# Altium schematic sheet PreCharge.SchDoc(PreCharge)
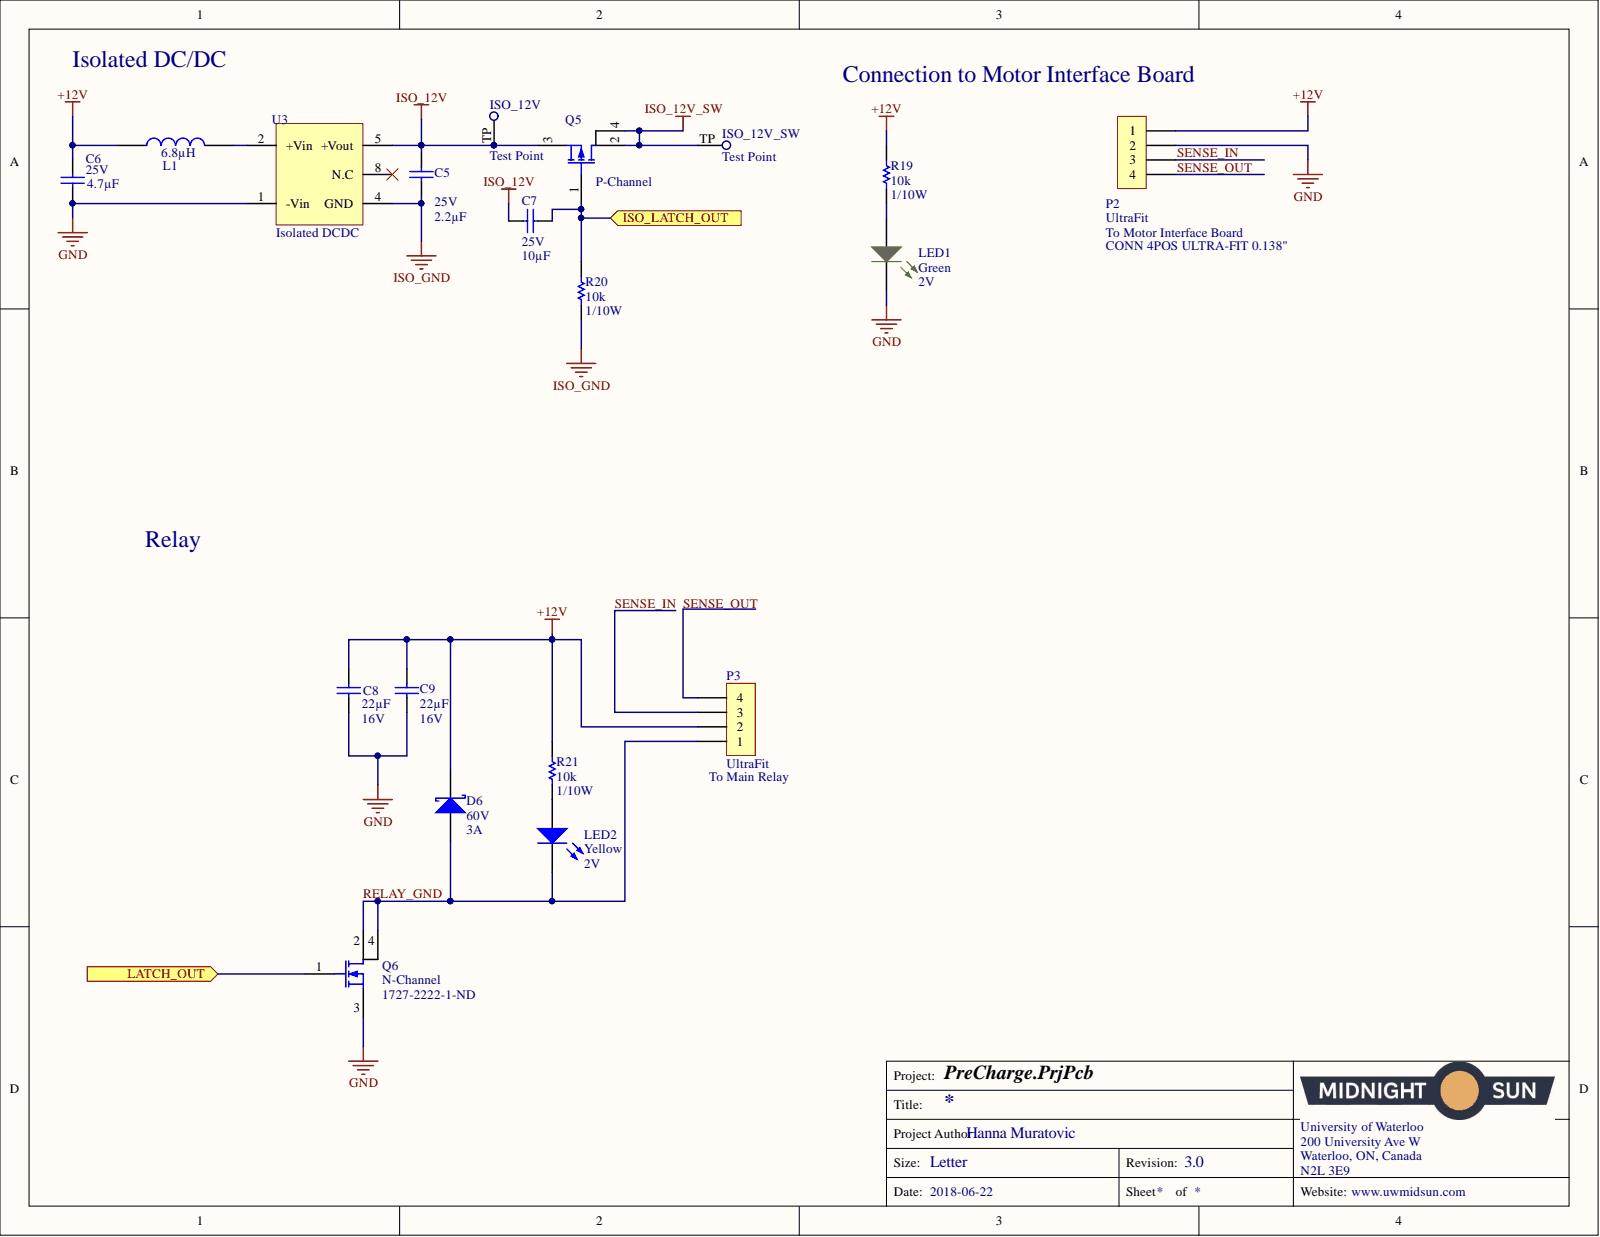
[diagram p0: PreCharge.SchDoc]

## Parts
C5  Voltage: 25V  Capacitance: 2.2µF  [CAP, 0603]  (hidden comment: CAP CER 2.2UF 25V 10% X5R 0603)
C6  Voltage: 25V  Capacitance: 4.7µF  [CAP, 0603]  (hidden comment: CAP CER 4.7UF 25V 10% X5R 0603)
C7  Voltage - Rated: 25V  Capacitance: 10µF  [CAP, 0805]  (hidden comment: CAP CER 10uF 25V 10% X5R 0805)
C8  Voltage: 16V  Capacitance: 22µF  [CAP, 1210]  (hidden comment: CAP CER 22UF 16V ±20% X5R 1210)
C9  Voltage: 16V  Capacitance: 22µF  [CAP, 1210]  (hidden comment: CAP CER 22UF 16V ±20% X5R 1210)
D6  Voltage - DC Reverse (Vr) (Max): 60V  Current - Average Rectified (Io): 3A  [SMA]  (hidden comment: DIODE SCHOTTKY 60V 3A SMA)
ISO_12V  Test Point  [Test Point]  (no BOM)
ISO_12V_SW  Test Point  [Test Point]  (no BOM)
L1  Inductance: 6.8µH  [NLFV32T-6R8M-EF/IND 6.8uH]  (hidden comment: IND 6.8uH 260mA 20% 1210)
LED1  Voltage - Forward (Vf) (Typ): 2V  Color: Green  [LED_0603]  (hidden comment: LED GREEN CLEAR 2V 0603)
LED2  Voltage - Forward (Vf) (Typ): 2V  Color: Yellow  [LED_0603]  (hidden comment: LED YELLOW CLEAR 2.1V 0603)
P2  CONN 4POS ULTRA-FIT 0.138"  [CONN, 4POS ULTRA-FIT]
P3  CONN 4POS ULTRA-FIT 0.138"  Series: UltraFit  [CONN, 4POS ULTRA-FIT]
Q5  P-Channel  [SOT223_N]
Q6  N-Channel  Supplier Part Number 1: 1727-2222-1-ND  [SOT223_N]
R19  Resistance: 10k  Power: 1/10W  [RES, 0603]  (hidden comment: RES 10K OHM 1% 1/10W 0603)
R20  Resistance: 10k  Power: 1/10W  [RES, 0603]  (hidden comment: RES 10K OHM 1% 1/10W 0603)
R21  Resistance: 10k  Power: 1/10W  [RES, 0603]  (hidden comment: RES 10K OHM 1% 1/10W 0603)
U3  Type: Isolated DCDC  [IC, Isolated DCDC]  (hidden comment: IC DCDC ISOLATED 12V 1W 8-SMD 5-LEAD)

## Ports
ISO_LATCH_OUT, LATCH_OUT

## Nets
+12V: C6.2 C8.1 C9.1 D6.2 L1.1 P2.1 P3.2 R19.2 R21.1
GND: C6.1 C8.2 C9.2 LED1.2 P2.2 Q6.3 U3.1(-Vin)
ISO_12V: C5.2 C7.1 ISO_12V.TP Q5.3 U3.5(+Vout)
ISO_12V_SW: ISO_12V_SW.TP Q5.2 Q5.4
ISO_GND: C5.1 R20.2 U3.4(GND)
ISO_LATCH_OUT: C7.2 Q5.1 R20.1 ; port ISO_LATCH_OUT
LATCH_OUT: Q6.1 ; port LATCH_OUT
RELAY_GND: D6.1 LED2.2 P3.1 Q6.2 Q6.4
SENSE_IN: P2.3 P3.3
SENSE_OUT: P2.4 P3.4
NetL1_2: L1.2 U3.2(+Vin)
NetLED1_1: LED1.1 R19.1
NetLED2_1: LED2.1 R21.2

Not connected: U3 8(N.C)

## Library data of the parts
C5 = CAP CER 2.2UF 25V 10% X5R 0603: 2.2µF ±10% 25V Ceramic Capacitor X5R 0603 (1608 Metric); Manufacturer Part Number 1: GRM188R61E225KA12D; Manufacturer 1: Murata Electronics North America; Tolerance: ±10%; Thickness (Max): 0.035" (0.90mm); Temperature Coefficient: X5R; Supplier Part Number 1: 490-10731-1-ND; Supplier 1: Digi-Key; Size / Dimension: 0.063" L x 0.031" W (1.60mm x 0.80mm); Ratings: -; Part Status: Active; Packaging: Cut Tape (CT); Package / Case: 0603 (1608 Metric); Operating Temperature: -55°C ~ 85°C; Mounting Type: Surface Mount, MLCC; Lead Style: -; Lead Spacing: -; Height - Seated (Max): -; ComponentLink1URL: http://www.murata.com/~/media/webrenewal/support/library/catalog/products/capacitor/mlcc/c02e.ashx; ComponentLink1Description: http://www.murata.com/~/media/webrenewal/support/library/catalog/products/capacitor/mlcc/c02e.ashx; Component Kind: Standard; Library Name: Schematic Diagrams.SchLib
C6 = CAP CER 4.7UF 25V 10% X5R 0603: 4.7µF ±10% 25V Ceramic Capacitor X5R 0603 (1608 Metric); Manufacturer Part Number 1: GRM188R61E475KE11D; Manufacturer 1: Murata Electronics North America; Tolerance: ±10%; Thickness (Max): 0.031" (0.80mm); Temperature Coefficient: X5R; Supplier Part Number 1: 490-7203-1-ND; Supplier 1: Digi-Key; Size / Dimension: 0.063" L x 0.031" W (1.60mm x 0.80mm); Ratings: -; Part Status: Active; Packaging: Cut Tape (CT); Package / Case: 0603 (1608 Metric); Operating Temperature: -55°C ~ 85°C; Mounting Type: Surface Mount, MLCC; Lead Style: -; Lead Spacing: -; Height - Seated (Max): -; ComponentLink1URL: http://www.murata.com/~/media/webrenewal/support/library/catalog/products/capacitor/mlcc/c02e.ashx; ComponentLink1Description: http://www.murata.com/~/media/webrenewal/support/library/catalog/products/capacitor/mlcc/c02e.ashx; Component Kind: Standard; Library Name: Schematic Diagrams.SchLib
C7 = CAP CER 10uF 25V 10% X5R 0805: 10µF ±10% 25V Ceramic Capacitor X5R 0805 (2012 Metric); Manufacturer Part Number: GRM21BR61E106KA73L; Manufacturer: Murata Electronics North America; Tolerance: ±10%; Thickness (Max): 0.053" (1.35mm); Temperature Coefficient: X5R; Supplier Part Number 1: 490-5523-1-ND; Supplier 1: Digi-Key; Stock 1: 1695157; Size / Dimension: 0.079" L x 0.049" W (2.00mm x 1.25mm); RoHS: RoHS Compliant; Ratings: -; Pricing 1: 1=0.3, 10=0.214, 100=0.1008, 500=0.07068, 1000=0.05851 (CAD); Part Status: Active; Packaging: Cut Tape (CT); Package / Case: 0805 (2012 Metric); Operating Temperature: -55°C ~ 85°C; Mounting Type: Surface Mount, MLCC; Lead Style: -; Lead Spacing: -; Height - Seated (Max): -; Features: -; ComponentLink1URL: http://search.murata.co.jp/Ceramy/image/img/A01X/G101/ENG/GRM21BR61E106KA73-01.pdf; ComponentLink1Description: http://search.murata.co.jp/Ceramy/image/img/A01X/G101/ENG/GRM21BR61E106KA73-01.pdf; Component Kind: Standard; Category: Capacitors; Applications: General Purpose; Library Name: Schematic Diagrams.SchLib
C8 C9 = CAP CER 22UF 16V ±20% X5R 1210: 22µF ±20% 16V Ceramic Capacitor X5R 1210 (3225 Metric); Manufacturer Part Number 1: GRM32ER61C226ME20L; Manufacturer 1: Murata Electronics North America; Tolerance: ±20%; Thickness (Max): 0.106" (2.70mm); Temperature Coefficient: X5R; Supplier Part Number 1: 490-1881-1-ND; Supplier 1: Digi-Key; Size / Dimension: 0.126" L x 0.098" W (3.20mm x 2.50mm); Ratings: -; Part Status: Active; Packaging: Cut Tape (CT); Package / Case: 1210 (3225 Metric); Operating Temperature: -55°C ~ 85°C; Mounting Type: Surface Mount, MLCC; Lead Style: -; Lead Spacing: -; Height - Seated (Max): -; ComponentLink1URL: http://www.murata.com/~/media/webrenewal/support/library/catalog/products/capacitor/mlcc/c02e.ashx; ComponentLink1Description: http://www.murata.com/~/media/webrenewal/support/library/catalog/products/capacitor/mlcc/c02e.ashx; Component Kind: Standard; Library Name: Schematic Diagrams.SchLib
D6 = DIODE SCHOTTKY 60V 3A SMA: Diode Schottky 60V 3A Surface Mount SMA; Manufacturer Part Number 1: B360A-13-F; Manufacturer 1: Diodes Incorporated; Voltage - Forward (Vf) (Max) @ If: 700mV @ 3A; Speed: Fast Recovery =< 500ns, > 200mA (Io); Current - Reverse Leakage @ Vr: 500µA @ 60V; Capacitance @ Vr, F: 200pF @ 4V, 1MHz; Supplier Part Number 1: B360A-FDICT-ND; Supplier Device Package: SMA; Supplier 1: Digi-Key; Part Status: Active; Packaging: Cut Tape (CT); Package / Case: DO-214AC, SMA; Operating Temperature - Junction: -55°C ~ 150°C; Mounting Type: Surface Mount; Diode Type: Schottky; ComponentLink1URL: https://www.diodes.com/assets/Datasheets/ds30891.pdf; ComponentLink1Description: https://www.diodes.com/assets/Datasheets/ds30891.pdf; Component Kind: Standard; Library Name: Schematic Diagrams.SchLib
L1 = IND 6.8uH 260mA 20% 1210: 6.8µH Shielded Wirewound Inductor 260mA 204 mOhm Max 1210 (3225 Metric); Manufacturer Part Number 1: NLFV32T-6R8M-EF; Manufacturer 1: TDK Corporation; Material - Core: Ferrite; Type: Wirewound; Tolerance: ±20%; Supplier Part Number 1: 445-15776-1-ND; Supplier Device Package: 1210 (3225 Metric); Supplier 1: Digi-Key; Size / Dimension: 0.126" L x 0.098" W (3.20mm x 2.50mm); Shielding: Shielded; Ratings: -; Q @ Freq: -; Part Status: Active; Packaging: Cut Tape (CT); Package / Case: 1210 (3225 Metric); Operating Temperature: -40°C ~ 105°C; Mounting Type: Surface Mount; Height - Seated (Max): 0.094" (2.40mm); Frequency - Self Resonant: -; DC Resistance (DCR): 204 mOhm Max; Current Rating: 260mA; Current - Saturation: -; ComponentLink1URL: https://product.tdk.com/info/en/catalog/datasheets/inductor_commercial_decoupling_nlfv32-ef_en.pdf; ComponentLink1Description: https://product.tdk.com/info/en/catalog/datasheets/inductor_commercial_decoupling_nlfv32-ef_en.pdf; Component Kind: Standard; Library Name: Schematic Diagrams.SchLib
LED1 = LED GREEN CLEAR 2V 0603: Green 572nm LED Indication - Discrete 2V 0603 (1608 Metric); Manufacturer Part Number: 150060VS75000; Manufacturer: Wurth Electronics Inc.; Wavelength - Dominant: 570nm; Viewing Angle: 140°; Millicandela Rating: 40mcd; Lens Style/Size: Rectangle with Flat Top, 1.10mm x 0.70mm; Lens Color: Colorless; Current - Test: 20mA; Wavelength - Peak: 572nm; Supplier Part Number 1: 732-4980-1-ND; Supplier Device Package: 0603; Supplier 1: Digi-Key; Stock 1: 9408; Size / Dimension: 1.60mm L x 0.80mm W; RoHS: RoHS Compliant; Pricing 1: 1=0.21, 100=0.1617, 500=0.13964 (CAD); Part Status: Active; Packaging: Cut Tape (CT); Package / Case: 0603 (1608 Metric); Mounting Type: Surface Mount; Lens Transparency: Clear; Height (Max): 0.80mm; Features: -; ComponentLink1URL: http://katalog.we-online.de/led/datasheet/150060VS75000.pdf; ComponentLink1Description: http://katalog.we-online.de/led/datasheet/150060VS75000.pdf; Component Kind: Standard; Category: Optoelectronics; Library Name: Schematic Diagrams.SchLib
LED2 = LED YELLOW CLEAR 2.1V 0603: Yellow 590nm LED Indication - Discrete 2V 0603 (1608 Metric); Manufacturer Part Number: 150060YS75000; Manufacturer: Wurth Electronics Inc.; Wavelength - Peak: 595nm; Viewing Angle: 140°; Millicandela Rating: 120mcd; Lens Style/Size: Rectangle with Flat Top, 1.10mm x 0.70mm; Lens Color: Colorless; Current - Test: 20mA; Wavelength - Dominant: 590nm; Supplier Part Number 1: 732-4981-1-ND; Supplier Device Package: 0603; Supplier 1: Digi-Key; Stock 1: 10523; Size / Dimension: 1.60mm L x 0.80mm W; RoHS: RoHS Compliant; Pricing 1: 1=0.21, 100=0.1617, 500=0.13964 (CAD); Part Status: Active; Packaging: Cut Tape (CT); Package / Case: 0603 (1608 Metric); Mounting Type: Surface Mount; Lens Transparency: Clear; Height (Max): 0.80mm; Features: -; ComponentLink1URL: http://katalog.we-online.de/led/datasheet/150060YS75000.pdf; ComponentLink1Description: http://katalog.we-online.de/led/datasheet/150060YS75000.pdf; Component Kind: Standard; Category: Optoelectronics; Library Name: Schematic Diagrams.SchLib
P2 P3 = CONN 4POS ULTRA-FIT 0.138": 4 Positions Header Connector 0.138" (3.50mm) Through Hole Tin; Manufacturer Part Number 1: 1722871104; Manufacturer 1: Molex, LLC; Voltage: 400V; Style: Board to Cable/Wire; Shrouding: Shrouded - 4 Wall; Number of Positions Loaded: All; Number of Positions: 4; Material Flammability Rating: UL94 V-0; Mated Stacking Heights: 26.99mm; Contact Length - Post: 0.126" (3.20mm); Termination: Solder; Supplier Part Number 1: WM11703-ND; Supplier 1: Digi-Key; Row Spacing - Mating: -; Pitch - Mating: 0.138" (3.50mm); Part Status: Active; Packaging: Tray; Overall Contact Length: -; Operating Temperature: -40°C ~ 105°C; Number of Rows: 1; Mounting Type: Through Hole; Insulation Material: Liquid Crystal Polymer (LCP); Insulation Height: 0.465" (11.80mm); Insulation Color: Black; Ingress Protection: -; Fastening Type: Latch Holder; Current Rating: -; Contact Type: Male Pin; Contact Shape: Square; Contact Material: Copper Alloy; Contact Length - Mating: -; Contact Finish Thickness - Post: 60µin (1.52µm); Contact Finish Thickness - Mating: 60µin (1.52µm); Contact Finish - Post: Tin; Contact Finish - Mating: Tin; Connector Type: Header; ComponentLink1URL: http://www.molex.com/pdm_docs/sd/1722871104_sd.pdf; ComponentLink1Description: http://www.molex.com/pdm_docs/sd/1722871104_sd.pdf; Component Kind: Standard; Library Name: Schematic Diagrams.SchLib
  P2: Series: UltraFit
Q5 = MOSFET P-CH 60V 3A SOT223: P-Channel 60V 3A (Ta) 2W (Ta) Surface Mount SOT-223; Manufacturer Part Number: ZXMP6A17GQTA; Manufacturer: Diodes; Turn-On Delay Time: 2.6ns; Turn-Off Delay Time: 26.2ns; Rise Time: 3.4ns; Rds On Max: 125mR; Number of Channels: 1; Input Capacitance: 637pF; Gate to Source Voltage (Vgs): 20V; Fall Time: 11.3ns; Element Configuration: Single; Drain to Source Resistance: 190mR; Drain to Source Breakdown Voltage: -60V; Weight: 0.000282oz; Supplier Part Number 1: ZXMP6A17GQTADIDKR-ND; Supplier 1: Digi-Key; Stock 1: 815; REACH SVHC: No SVHC; Radiation Hardening: No; Pricing 1: 1=0.9, 10=0.788, 100=0.6076, 500=0.45012 (USD); Packaging: Tape and Reel; Package Quantity: 1; Number of Pins: 3; Mount: Surface Mount; Min Operating Temperature: -55°C; Max Power Dissipation: 2W; Max Operating Temperature: 150°C; Lead Free: Lead Free; Drain to Source Voltage (Vdss): 60V; Continuous Drain Current (ID): 3A; ComponentLink3URL: https://datasheet.ciiva.com/29747/zxmp6a17gq-29747438.pdf; ComponentLink3Description: https://datasheet.ciiva.com/29747/zxmp6a17gq-29747438.pdf; ComponentLink2URL: https://datasheet.ciiva.com/29747/zxmp6a17gq-29747434.pdf; ComponentLink2Description: https://datasheet.ciiva.com/29747/zxmp6a17gq-29747434.pdf; ComponentLink1URL: https://datasheet.ciiva.com/29747/zxmp6a17gq-29747441.pdf; ComponentLink1Description: https://datasheet.ciiva.com/29747/zxmp6a17gq-29747441.pdf; Component Kind: Standard; Case/Package: TO-261-4; Library Name: Schematic Diagrams.SchLib
Q6 = MOSFET N-CH 55V 7A SOT223: N-Channel 55V 7A (Tc) 8W (Tc) Surface Mount SOT-223; Manufacturer Part Number: BUK7880-55A/CUX; Manufacturer: Nexperia; Supplier 1: Digi-Key; Stock 1: 0; ComponentLink1URL: https://assets.nexperia.com/documents/data-sheet/BUK7880-55A.pdf; ComponentLink1Description: https://assets.nexperia.com/documents/data-sheet/BUK7880-55A.pdf; Component Kind: Standard; Library Name: Schematic Diagrams.SchLib
R19-R21 = RES 10K OHM 1% 1/10W 0603: RES SMD 10K OHM 1% 1/10W 0603; Manufacturer Part Number 1: RC0603FR-0710KL; Manufacturer 1: Yageo; Temperature Coefficient: ±100ppm/°C; Tolerance: ±1%; Supplier Part Number 1: 311-10.0KHRCT-ND; Supplier Device Package: 0603; Supplier 1: Digi-Key; Size / Dimension: 0.063" L x 0.031" W (1.60mm x 0.80mm); Part Status: Active; Packaging: Cut Tape (CT); Package / Case: 0603 (1608 Metric); Operating Temperature: -55°C ~ 155°C; Height - Seated (Max): 0.022" (0.55mm); Failure Rate: -; Composition: Thick Film; ComponentLink1URL: http://www.yageo.com/documents/recent/PYu-RC_Group_51_RoHS_L_7.pdf; ComponentLink1Description: http://www.yageo.com/documents/recent/PYu-RC_Group_51_RoHS_L_7.pdf; Component Kind: Standard; Library Name: Schematic Diagrams.SchLib
U3 = IC DCDC ISOLATED 12V 1W 8-SMD 5-LEAD: Isolated Module DC DC Converter 1 Output 12V 84mA 10.8V - 13.2V Input; Manufacturer Part Number 1: ISE1212A; Manufacturer 1: XP Power; Voltage - Isolation: 1.5kV (1500V); Number of Outputs: 1; Efficiency: 81%; Voltage - Output 3: -; Voltage - Output 2: -; Voltage - Output 1: 12V; Voltage - Input (Min): 10.8V; Voltage - Input (Max): 13.2V; Supplier Part Number 1: 1470-2950-1-ND; Supplier 1: Digi-Key; Size / Dimension: 0.50" L x 0.44" W x 0.29" H (12.7mm x 11.2mm x 7.3mm); Power: 1W; Part Status: Active; Packaging: Cut Tape (CT); Package / Case: 8-SMD Module, 5 Leads; Operating Temperature: -40°C ~ 105°C; Mounting Type: Surface Mount; Current - Output (Max): 84mA; ComponentLink1URL: http://www.xppower.com/Portals/0/pdfs/SF_ISE.pdf; ComponentLink1Description: http://www.xppower.com/Portals/0/pdfs/SF_ISE.pdf; Component Kind: Standard; Library Name: Schematic Diagrams.SchLib
ISO_12V ISO_12V_SW = Test Point: Library Name: Schematic Diagrams.SchLib
